# Altium schematic sheet USB Hub.SchDoc("USB Hub")
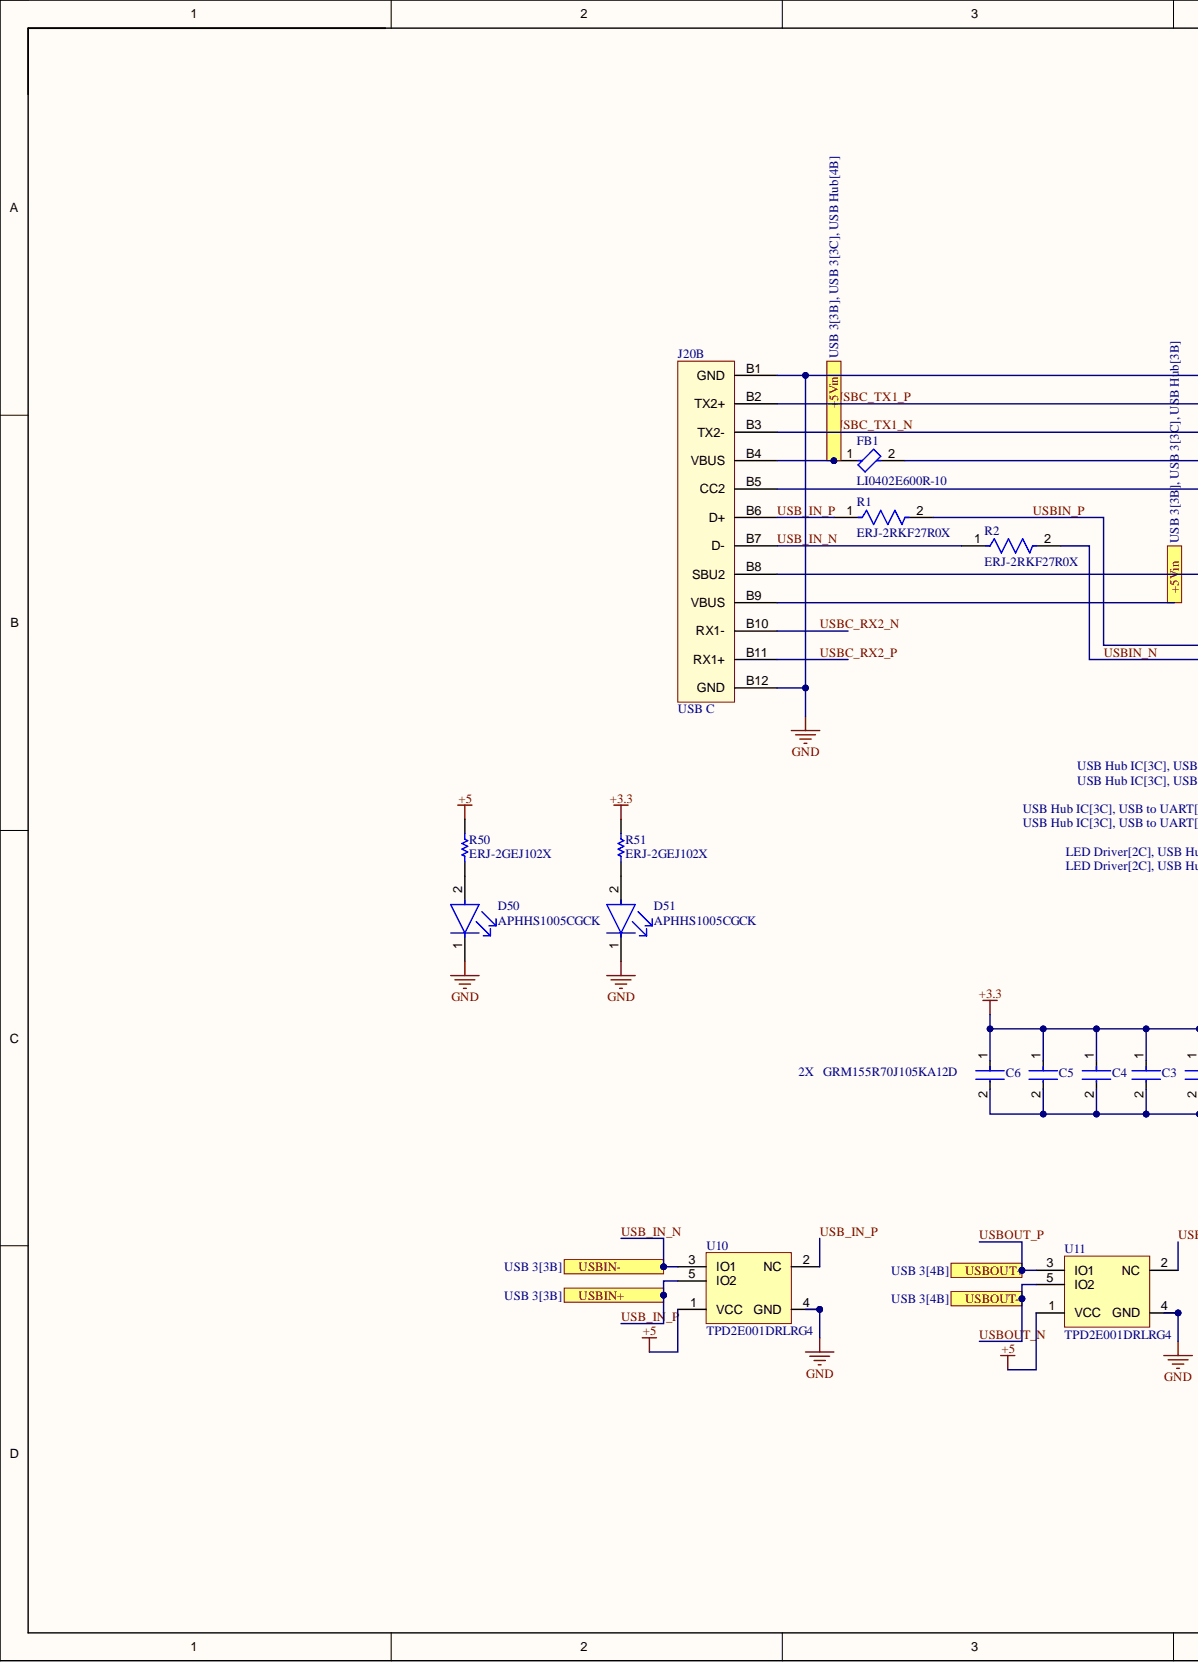
[diagram p0: USB Hub.SchDoc, left]
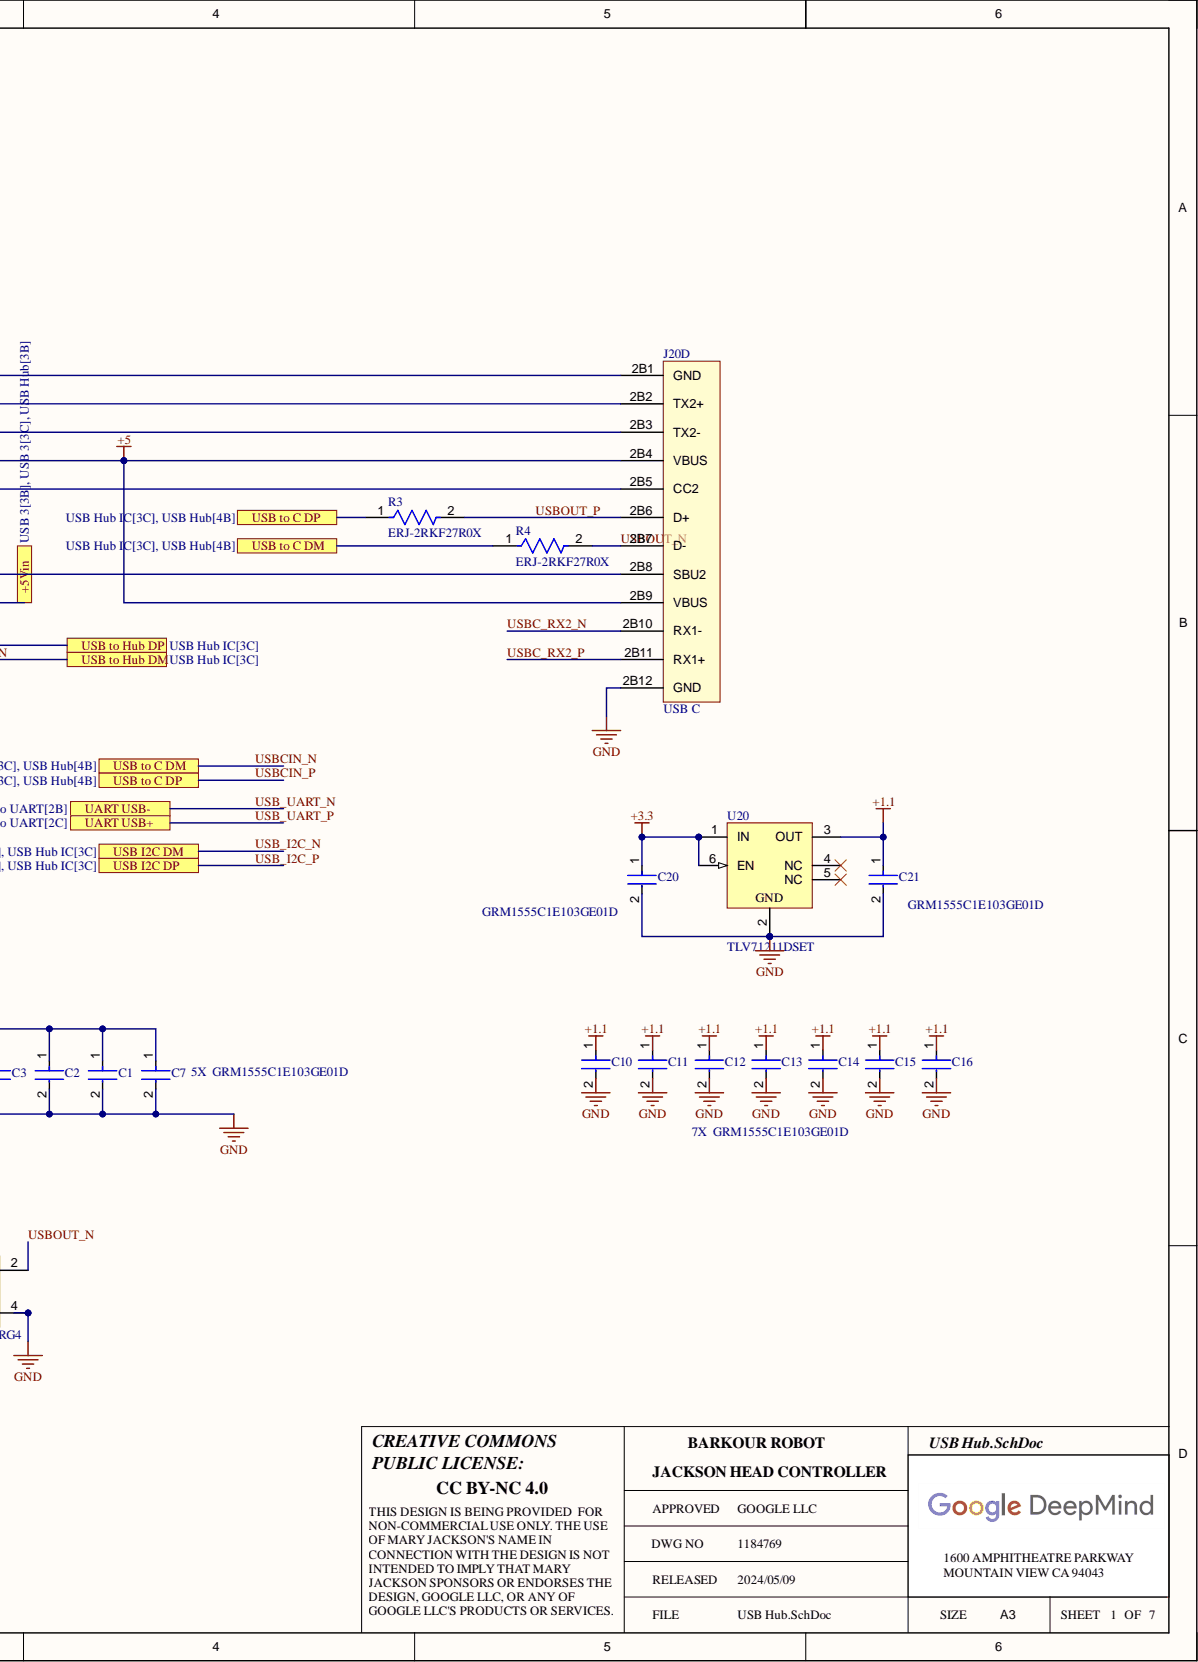
[diagram p1: USB Hub.SchDoc, right]

## Parts
C1  GRM1555C1E103GE01D  [FP-GRM155-0_15-IPC_B]
C2  GRM1555C1E103GE01D  [FP-GRM155-0_15-IPC_B]
C3  GRM1555C1E103GE01D  [FP-GRM155-0_15-IPC_B]
C4  GRM1555C1E103GE01D  [FP-GRM155-0_15-IPC_B]
C5  GRM155R70J105KA12D  [FP-GRM155-0_05-MFG]
C6  GRM155R70J105KA12D  [FP-GRM155-0_05-MFG]
C7  GRM1555C1E103GE01D  [FP-GRM155-0_15-IPC_B]
C10  GRM1555C1E103GE01D  [FP-GRM155-0_15-IPC_B]
C11  GRM1555C1E103GE01D  [FP-GRM155-0_15-IPC_B]
C12  GRM1555C1E103GE01D  [FP-GRM155-0_15-IPC_B]
C13  GRM1555C1E103GE01D  [FP-GRM155-0_15-IPC_B]
C14  GRM1555C1E103GE01D  [FP-GRM155-0_15-IPC_B]
C15  GRM1555C1E103GE01D  [FP-GRM155-0_15-IPC_B]
C16  GRM1555C1E103GE01D  [FP-GRM155-0_15-IPC_B]
C20  GRM1555C1E103GE01D  [FP-GRM155-0_15-IPC_B]
C21  GRM1555C1E103GE01D  [FP-GRM155-0_15-IPC_B]
D50  APHHS1005CGCK  [FP-APHHS1005CGCK-MFG]
D51  APHHS1005CGCK  [FP-APHHS1005CGCK-MFG]
FB1  LI0402E600R-10  [FP-LI0402-MFG]
J20  USB C  [Dual USB C Connector]  (parts B,D)
R1  ERJ-2RKF27R0X  [FP-ERJ2R-IPC_B]
R2  ERJ-2RKF27R0X  [FP-ERJ2R-IPC_B]
R3  ERJ-2RKF27R0X  [FP-ERJ2R-IPC_B]
R4  ERJ-2RKF27R0X  [FP-ERJ2R-IPC_B]
R50  ERJ-2GEJ102X  [RESC1005X40X25NL05T05]
R51  ERJ-2GEJ102X  [RESC1005X40X25NL05T05]
U10  TPD2E001DRLRG4  [DRL0005A_L]
U11  TPD2E001DRLRG4  [DRL0005A_L]
U20  TLV71211DSET  [DSE0006A_V]

## Ports
+5Vin, UART USB+, UART USB-, USB I2C DM, USB I2C DP, USB to C DM, USB to C DP, USB to Hub DM, USB to Hub DP, USBIN+, USBIN-, USBOUT+, USBOUT-

## Nets
+1.1: C10.1 C11.1 C12.1 C13.1 C14.1 C15.1 C16.1 C21.1 U20.3(OUT)
+3.3: C1.1 C2.1 C3.1 C4.1 C5.1 C6.1 C7.1 C20.1 R51.2 U20.1(IN) U20.6(EN)
+5: FB1.2 J20.2B4(VBUS) J20.2B9(VBUS) R50.2 U10.1(VCC) U11.1(VCC)
GND: C1.2 C2.2 C3.2 C4.2 C5.2 C6.2 C7.2 C10.2 C11.2 C12.2 C13.2 C14.2 C15.2 C16.2 C20.2 C21.2 D50.1 D51.1 J20.2B1(GND) J20.2B12(GND) J20.B1(GND) J20.B12(GND) U10.4(GND) U11.4(GND) U20.2
+5Vin: FB1.1 J20.B4(VBUS) J20.B9(VBUS) ; port +5Vin
USB_IN_N: J20.B7(D-) R2.1 U10.3(IO1)
USB_IN_P: J20.B6(D+) R1.1 U10.2(NC) U10.5(IO2)
USBC_RX2_N: J20.2B10(RX1-) J20.B10(RX1-)
USBC_RX2_P: J20.2B11(RX1+) J20.B11(RX1+)
USBC_TX1_N: J20.2B3(TX2-) J20.B3(TX2-)
USBC_TX1_P: J20.2B2(TX2+) J20.B2(TX2+)
USBCIN_N: R4.1 ; port USB to C DM
USBCIN_P: R3.1 ; port USB to C DP
USBIN_N: R2.2 ; port USB to Hub DM
USBIN_P: R1.2 ; port USB to Hub DP
USBOUT_N: J20.2B7(D-) R4.2 U11.2(NC) U11.5(IO2)
USBOUT_P: J20.2B6(D+) R3.2 U11.3(IO1)
NetD50_2: D50.2 R50.1
NetD51_2: D51.2 R51.1
NetJ20_2B5: J20.2B5(CC2) J20.B5(CC2)
NetJ20_2B8: J20.2B8(SBU2) J20.B8(SBU2)
USB_I2C_N: ; port USB I2C DM
USB_I2C_P: ; port USB I2C DP
USB_UART_N:
USB_UART_P:

Not connected: U20 4(NC) 5(NC)

## Library data of the parts
C1-C4 C7 C10-C16 C20 C21 = CMP-06035-007392-1: Chip Multilayer Ceramic Capacitors for General Purpose, 0402, 10000pF, C0G, 30ppm/°C, 2%, 25V; Component Kind: Standard; Library Name: Altium Content Vault
C5 C6 = CMP-2008-04587-2: Chip Multilayer Ceramic Capacitors for General Purpose, 0402, 1.0uF, X7R, 15%, 10%, 6.3V; Capacitance: 1uF; Case Code (Imperial): 0402; Case Code (Metric): 1005; Case/Package: 0402; Component Kind: Standard; Depth: 0.5mm; Dielectric: X7R; Height: 0.5mm; Lead Free: Lead Free; Length: 1mm; Library Name: Altium Content Vault; Material: Ceramic; Max Operating Temperature: 125°C; Min Operating Temperature: -55°C; Mount: Surface Mount; Package Quantity: 10000; Packaging: Tape and Reel; Termination: SMD/SMT; Thickness: 0.022inch; Tolerance: 10%; Voltage Rating: 6.3V; Voltage Rating (DC): 6.3V; Weight: 0.000212oz; Width: 0.5mm
D50 D51 = CMP-44529-000044-1: LED GREEN CLEAR CHIP SMD; Dominant Wavelength: 570nm; Forward Current: 20mA; Forward Voltage: 2.1V; Luminous Intensity: 50mcd; Number of LEDs: 1; Viewing Angle: 120°; Case Code (Imperial): 0402; Case Code (Metric): 1005; Case/Package: 0402; Color: Green; Component Kind: Standard; Height: 0.6mm; Illumination Color: Green; Lead Free: Lead Free; Length: 1mm; Lens Color: Clear; Lens Style: Clear; Library Name: Altium Content Vault; Max Operating Temperature: 85°C; Min Operating Temperature: -40°C; Mount: Surface Mount; Number of Pins: 2; Package Quantity: 2000; Packaging: Tape and Reel; Test Current: 20mA; Wavelength: 570nm; Width: 0.5mm
FB1 = CMP-19111-000011-1: FERRITE BEAD 60 OHM 0402 1LN; DC Resistance (DCR): 300mR; Frequency: 100MHz; Impedance: 60R; Max Current Rating: 500mA; Number of Lines: 1; Case Code (Imperial): 0402; Case Code (Metric): 1005; Case/Package: 0402; Component Kind: Standard; Core Material: Ferrite; Depth: 0.7mm; Height: 0.028inch; Lead Free: Lead Free; Length: 0.04inch; Library Name: Altium Content Vault; Max DC Current: 500mA; Max Operating Temperature: 125°C; Min Operating Temperature: -40°C; Mount: Surface Mount; Package Quantity: 1; Packaging: Tape and Reel; Termination: SMD/SMT; Test Frequency: 100MHz; Weight: 0.00192oz; Width: 0.02inch
R1-R4 = CMP-2002-00830-2: RES SMD 27 OHM 1% 1/10W 0402; Max Power Dissipation: 100mW; Resistance: 27R; Temperature Coefficient: 100ppm/°C; Voltage Rating: 50V; Case Code (Imperial): 0402; Case Code (Metric): 1005; Case/Package: 0402; Component Kind: Standard; Construction: Rectangular; Contact Plating: Tin; Depth: 0.5mm; Features: Automotive AEC-Q200; Height: 0.35mm; Lead Free: Lead Free; Length: 1mm; Library Name: Altium Content Vault; Max Operating Temperature: 155°C; Military Standard: Not; Min Operating Temperature: -55°C; Mount: Surface Mount; Number of Pins: 2; Number of Terminations: 2; Package Quantity: 1; Packaging: Tape and Reel; Power Rating: 100mW; Radiation Hardening: No; REACH SVHC: No SVHC; Resistance Tolerance: 1%; Resistor Type: Thick Film; RoHS Compliant: Yes; Series: ERJ; Technology: THICK FILM; Termination: SMD/SMT; Tolerance: 1%; Voltage Rating (DC): 50V; Weight: 2.8E-05oz; Width: 0.5mm
R50 R51 = CMP-2002-00432-1: Manufacturer: Panasonic; Component Kind: Standard; Library Name: Altium Content Vault; Part Number: ERJ-2GEJ102X
U10 U11 = CMP-0326-00050-2: Low-Capacitance + / - 15 kV ESD-Protection Array for High-Speed Data Interfaces, 2 Channels, -40 to +85 degC, 5-pin SOT (DRL), Green (RoHS & no Sb/Br); Manufacturer: Texas Instruments; Breakdown Voltage(Min)(V): 11; IEC 61000-4-2 Air-Gap(+/- kV): 15; IEC 61000-4-2 Contact(+/- kV): 8; IO Capacitance(Typ)(pF): 1.5; IO Leakage Current(nA): 1; SubFamily: ESD Solution; USB High Speed: TRUE; Component Kind: Standard; ComponentLink1Description: Manufacturer URL; ComponentLink1URL: http://www.ti.com/; ComponentLink2Description: Datasheet; ComponentLink2URL: http://www.ti.com/general/docs/lit/getliterature.tsp?genericPartNumber=TPD2E001&fileType=pdf; ComponentLink3Description: URL Link1; ComponentLink3URL: SamplesURL:http://www.ti.com/product/tpd2e001#samples; DatasheetVersion: SLLS684F; Eco-Plan: Green (RoHS & no Sb/Br); Library Name: Altium Content Vault; Mounting Technology: Surface Mount; PackageDescription: 5-Pin Plastic Small Outline, Flat Lead 0.5 mm Pitch; PackageReference: DRL0005A; PackageVersion: revD, Aug-2007; PartNumber: TPD2E001DRLRG4
U20 = CMP-0394-01454-2: Single Output LDO, 300 mA, Fixed 1.1 V Output, 2 to 5.5 V Input, with Low IQ, 6-pin WSON (DSE), -40 to 125 degC, Green (RoHS & no Sb/Br); Manufacturer: Texas Instruments; Accuracy(%): 2; Fixed Output Options(V): 1.1; Iout(Max)(A): 0.3; Iq(Typ)(mA): 0.035; SubFamily: Single Channel LDO; Vdo(Typ)(mV): 260; Vin(Max)(V): 5.5; Component Kind: Standard; ComponentLink1Description: Manufacturer URL; ComponentLink1URL: http://www.ti.com/; ComponentLink2Description: Datasheet; ComponentLink2URL: http://www.ti.com/general/docs/lit/getliterature.tsp?genericPartNumber=TLV71211&fileType=pdf; ComponentLink3Description: Package Specification; ComponentLink3URL: http://www.ti.com/litv/pdf/mpds287; DatasheetVersion: SBVS150A; Eco-Plan: Green (RoHS & no Sb/Br); Library Name: Altium Content Vault; Mounting Technology: Surface Mount; PackageDescription: 6-Pin Plastic Small Outline, Body 1.5 x 1.5 mm, Pitch 0.5 mm; PackageReference: DSE0006A; PackageVersion: 4207810/A; PartNumber: TLV71211DSET; Vin(Min)(V): 2; Vout Adj(Max)(V): 1.1; Vout Adj(Min)(V): 1.1
J20 = USB C: Component Kind: Standard; Library Name: Schlib1.SchLib
